# Altium schematic sheet [06] USB_C.SchDoc(BLK5)
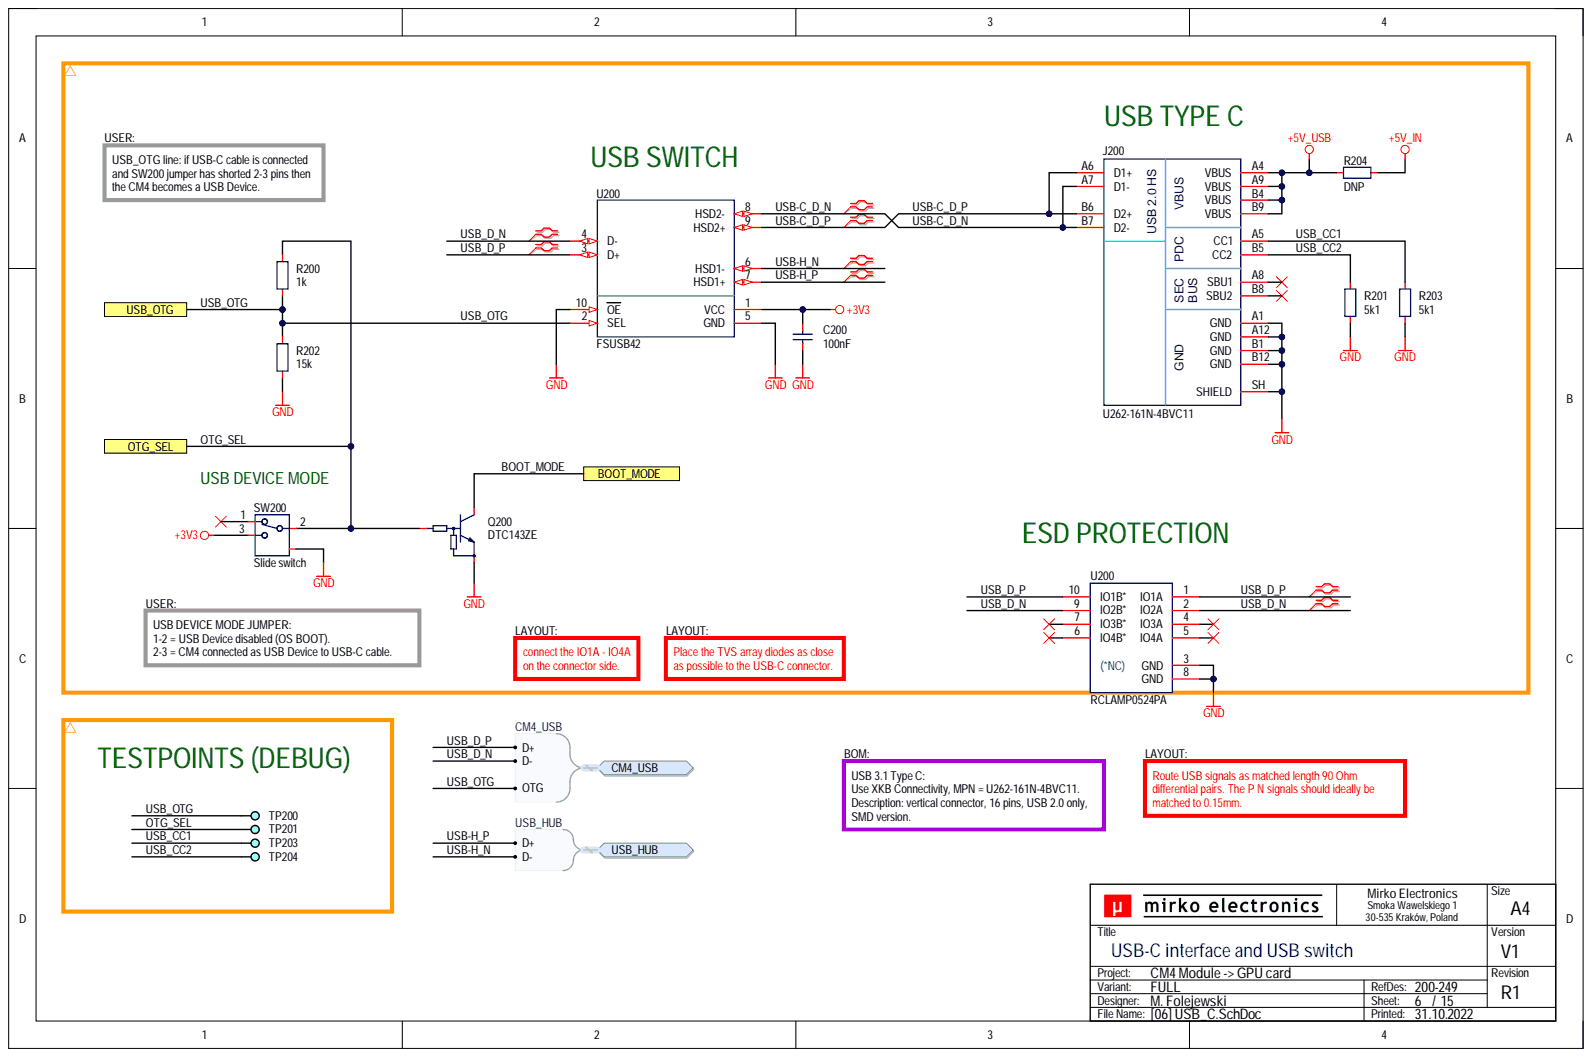
[diagram p0: [06] USB_C.SchDoc]

## Parts
C200  100nF  [CAP_0603_R]
J200  U262-161N-4BVC11  [CON_XKB_U262-161N-4BVC11]
Q200  DTC143ZE  [PKG_SOT523_SC75_SOT416_P100_R]
R200  1k  [RES_0603_R]
R201  5k1  [RES_0603_R]
R202  15k  [RES_0603_R]
R203  5k1  [RES_0603_R]
R204  DNP  [RES_1206_R]
SW200  Slide switch  Component Subtype: Slide  [SWI_XKB_SS-3292S-L1]
TP200  DEBUG  [PCB_TP_DBG_50]  (value not drawn)  (no BOM)
TP201  DEBUG  [PCB_TP_DBG_50]  (value not drawn)  (no BOM)
TP203  DEBUG  [PCB_TP_DBG_50]  (value not drawn)  (no BOM)
TP204  DEBUG  [PCB_TP_DBG_50]  (value not drawn)  (no BOM)
U200  FSUSB42  [ICC_MSOP10_300X300H110_P50_R]
U200  RCLAMP0524PA  [ICC_SLP2510P8_250X100X60_P50_R]

## Ports
BOOT_MODE, CM4_USB, CM4_USB.D+, CM4_USB.D-, CM4_USB.OTG, OTG_SEL, USB_HUB, USB_HUB.D+, USB_HUB.D-, USB_OTG

## Nets
+3V3: C200.2 SW200.3 U200.1
+5V_IN: R204.2
+5V_USB: J200.A4(VBUS) J200.A9(VBUS) J200.B4(VBUS) J200.B9(VBUS) R204.1
GND: C200.1 J200.A1(GND) J200.A12(GND) J200.B1(GND) J200.B12(GND) J200.SH(SHIELD) Q200.2 R201.2 R202.1 R203.2 SW200.SH U200.3 U200.5 U200.8 U200.10
BOOT_MODE: Q200.3 ; port BOOT_MODE
OTG_SEL: Q200.1 R200.2 SW200.2 TP201.1 ; port OTG_SEL
USB-C_D_N: J200.A7(D1-) J200.B7(D2-) U200.8
USB-C_D_P: J200.A6(D1+) J200.B6(D2+) U200.9
USB-H_N: U200.6 ; port USB_HUB
USB-H_P: U200.7 ; port USB_HUB
USB_CC1: J200.A5(CC1) R203.1 TP203.1
USB_CC2: J200.B5(CC2) R201.1 TP204.1
USB_D_N: U200.2 U200.4 U200.9 ; port CM4_USB
USB_D_P: U200.1 U200.3 U200.10 ; port CM4_USB
USB_OTG: R200.1 R202.2 TP200.1 U200.2 ; port CM4_USB, port USB_OTG

Not connected: J200 A8(SBU1) B8(SBU2); SW200 1

## Library data of the parts
C200 = CAP-0008: Capacitor; MLCC; 100nF; TBD; TBD; TBD; TBD; SMD; 0603 (1608);; Database Table Name: CAPACITOR$; Component Kind: Standard; Component Subtype: MLCC; Component Type: Capacitor; Manufacturer: TBD; Mounting Type: SMD; MPN: TBD; Package (Case): 0603 (1608); Supplier: ?; Value: 100nF; Library Name: MirFol_db_local.DbLib
J200 = CON_USB_TYPE_C_16P: Connector; USB 3.1 Type C; Receptacle; 24-pin; USB 2.0 Only;; Manufacturer: XKB Connectivity; Component Subtype: USB-C; Package (Case): SMD; Component Kind: Standard; Component Type: Connector; Mounting Type: SMD; MPN: U262-161N-4BVC11; Supplier: ?; Value: U262-161N-4BVC11; Library Name: MirFol_lib.SchLib
Q200 = TRA_BJT_NPN_DIG_BEC: Transistor; BJT; NPN; Digital;; Component Subtype: NPN Transistor; Component Kind: Standard; Component Type: Transistor; Mounting Type: SMD; MPN: DTC143ZE; Supplier: ?; Value: DTC143ZE; Library Name: MirFol_lib.SchLib
R200 = RES-0027: Resistor; Thick Film; 1k; 1%; 100mW; 100PPM; 75V; Standard; SMD; 0603 (1608);; Manufacturer: Yageo Corporation; MPN: TBD; Database Table Name: RESISTOR$; Component Kind: Standard; Component Subtype: Thick Film; Component Type: Resistor; Mounting Type: SMD; Package (Case): 0603 (1608); Supplier: ?; Value: 1k; Library Name: MirFol_db_local.DbLib
R201 R203 = RES-0043: Resistor; Thick Film; 5k1; 1%; 100mW; 100PPM; 75V; Standard; SMD; 0603 (1608);; Manufacturer: Yageo Corporation; MPN: TBD; Database Table Name: RESISTOR$; Component Kind: Standard; Component Subtype: Thick Film; Component Type: Resistor; Mounting Type: SMD; Package (Case): 0603 (1608); Supplier: ?; Value: 5k1; Library Name: MirFol_db_local.DbLib
R202 = RES-0043: Resistor; Thick Film; 15k; 1%; 100mW; 100PPM; 75V; Standard; SMD; 0603 (1608);; Manufacturer: Yageo Corporation; MPN: TBD; Database Table Name: RESISTOR$; Component Kind: Standard; Component Subtype: Thick Film; Component Type: Resistor; Mounting Type: SMD; Package (Case): 0603 (1608); Supplier: ?; Value: 15k; Library Name: MirFol_db_local.DbLib
R204 = RES-0060: Resistor; Thick Film; GENERIC; TBD; TBD; TBD; TBD; TBD; SMD; 1206 (3216);; Database Table Name: RESISTOR$; Component Body: TBD; Component Height: TBD; Component Kind: Standard; Component Subtype: Thick Film; Component Type: Resistor; Features: TBD; ID: RES-0060; Manufacturer: DNP; Mounting Type: SMD; MPN: DNP; Operating Temperature: TBD; Package (Case): 1206 (3216); Packaging Type: TBD; Power Rating: TBD; Resistance: DNP; ROHS Status: TBD; TCR: TBD; Tolerance: TBD; Value: DNP; Voltage Rating: TBD; Library Name: MirFol_db_local.DbLib
SW200 = SWI_SPDT_3P_SH1: Switch; SPDT;; Manufacturer: XKB Connectivity; MPN: SS-3292S-L1; Package (Case): SMD; Component Kind: Standard; Component Type: Switch; Mounting Type: SMD; Supplier: LCSC; Value: Slide switch; Library Name: MirFol_lib.SchLib
TP200 TP201 TP203 TP204 = PCB_TP_DBG: PCB Marking; Test Point (Debug);; Component Subtype: -; Component Type: Testpoint; Mounting Type: -; Supplier: ?; Value: DEBUG; Library Name: MirFol_lib.SchLib
U200 = ICC-0013: IC; USB Switch; TBD TBD; SMD; MSOP-10;; Manufacturer: ON Semiconductor; MPN: FSUSB42MUX; Database Table Name: IC (MISC)$; Component Kind: Standard; Component Subtype: USB Switch; Component Type: IC; Mounting Type: SMD; Package (Case): MSOP-10; Supplier: ?; Value: FSUSB42; Library Name: MirFol_db_local.DbLib
U200 = ICC-0005: IC; TVS Arrays; ESD Protection; 4-Channel; 17kV Air; 12kV Contact; 0.3pF Capitance; TBD; SMD; TBD;; Manufacturer: Semtech Corporation; MPN: RCLAMP0524PATCT; Database Table Name: IC (MISC)$; Component Kind: Standard; Component Subtype: TVS Arrays; Component Type: IC; Mounting Type: SMD; Package (Case): TBD; Supplier: ?; Value: RCLAMP0524PA; Library Name: MirFol_db_local.DbLib
